# Altium schematic sheet Schematic2.SchDoc
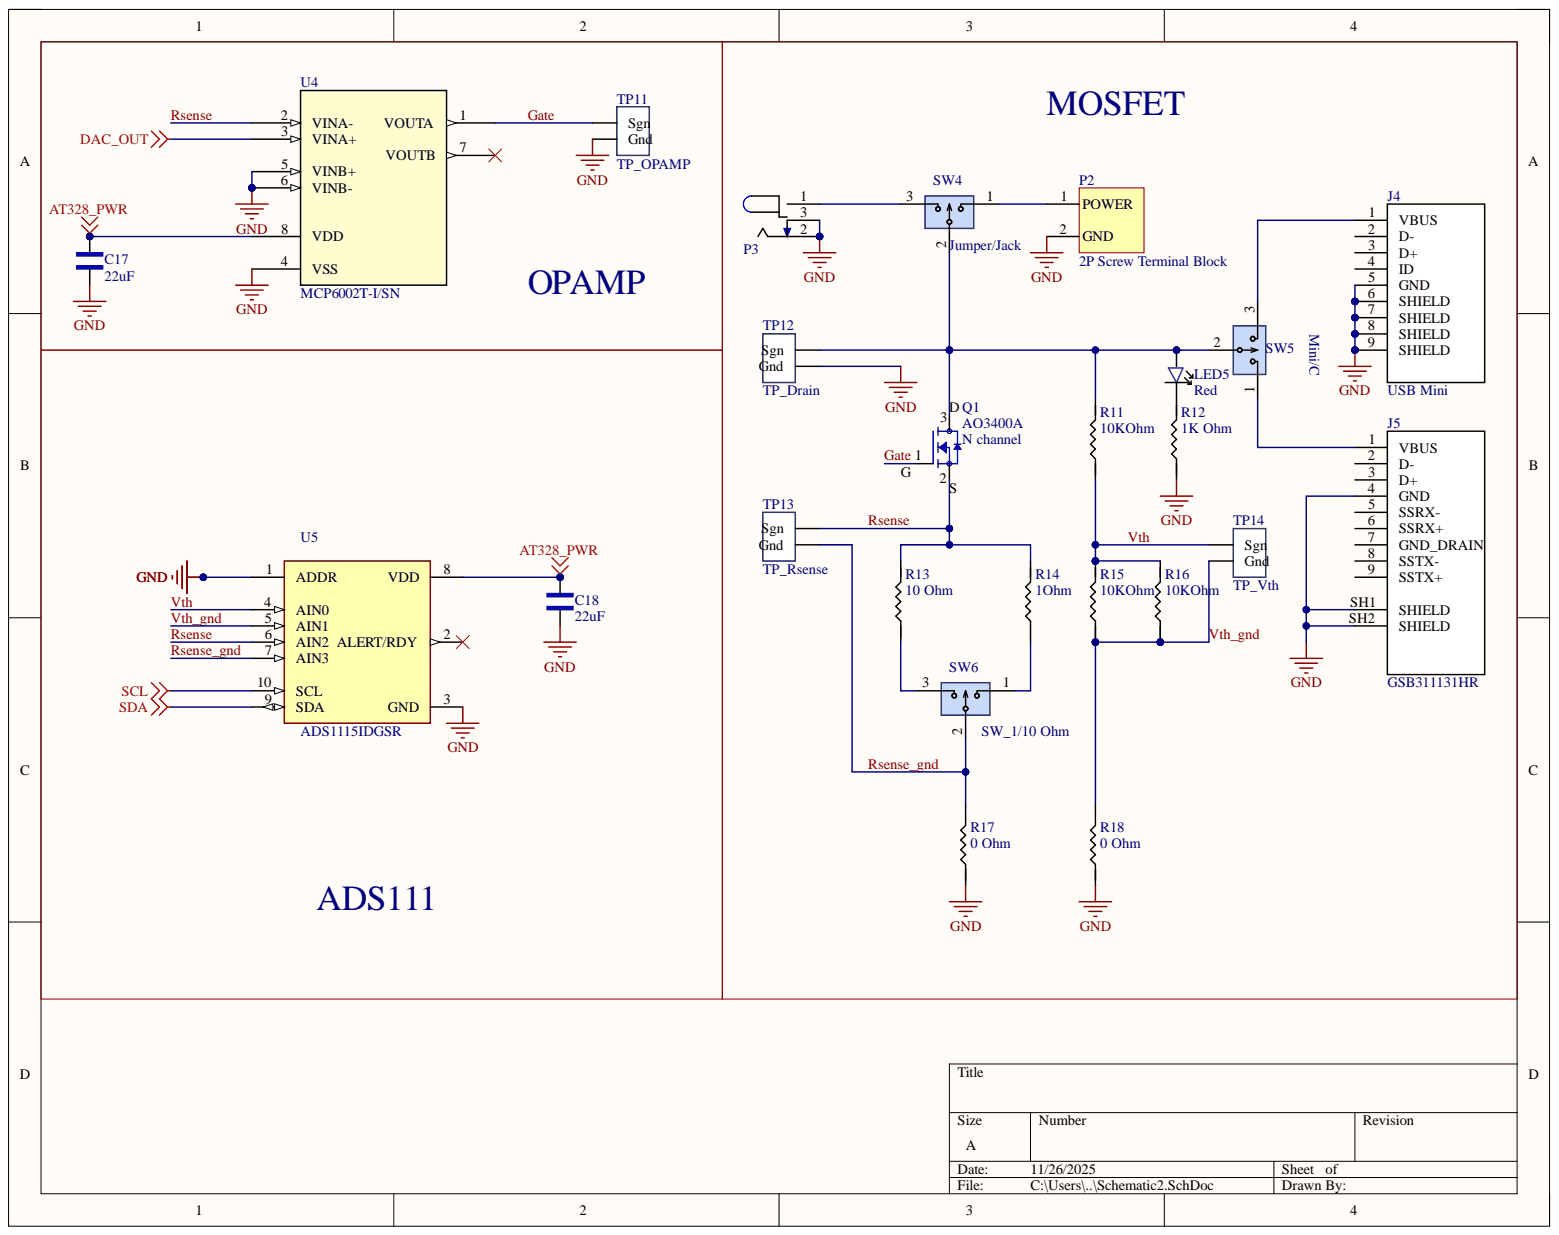
[diagram p0: Schematic2.SchDoc]

## Parts
C17  22uF  [1206_Passive_Capacitor]
C18  22uF  [1206_Passive_Capacitor]
J4  USB Mini  [USB_MINI_B]
J5  GSB311131HR  [USB_3A_Receptacle]
LED5  Red  [LED_1206]
P2  2P Screw Terminal Block  [P_Terminal block 3.5mm-pitch]
P3  Power Jack  [Power_Jack]  (value not drawn)
Q1  AO3400A  value N channel  [N-MOSFET]
R11  value 10KOhm  [1206_Passive_Resitor]  (hidden comment: 10k)
R12  value 1K Ohm  [1206_Passive_Resitor]  (hidden comment: 1k)
R13  10 Ohm  [1206_Passive_Resitor]
R14  1 Ohm  value 1Ohm  [1206_Passive_Resitor]
R15  value 10KOhm  [1206_Passive_Resitor]  (hidden comment: 10k)
R16  value 10KOhm  [1206_Passive_Resitor]  (hidden comment: 10k)
R17  0 Ohm  [1206_Passive_Resitor]
R18  0 Ohm  [1206_Passive_Resitor]
SW4  Jumper/Jack  [3_PIN_100mil]
SW5  Mini/C  [3_PIN_100mil]
SW6  SW_1/10 Ohm  [3_PIN_100mil]
TP11  TP_OPAMP  [TP10x_Probe]
TP12  TP_Drain  [TP10x_Probe]
TP13  TP_Rsense  [TP10x_Probe]
TP14  TP_Vth  [TP10x_Probe]
U4  MCP6002T-I/SN  [SOIC127P600X175-8N]
U5  ADS1115IDGSR  [ADC_ADS1115IDGSR]

## Nets
AT328_PWR: C17.2 C18.2 U4.8(VDD) U5.8(VDD)
DAC_OUT: U4.3(VINA+)
GND: C17.1 C18.1 J4.5(GND) J4.6(SHIELD) J4.7(SHIELD) J4.8(SHIELD) J4.9(SHIELD) J5.4(GND) J5.SH1(SHIELD) J5.SH2(SHIELD) P2.2 P3.2 P3.3 R12.1 R17.1 R18.1 TP11.2 TP12.2 U4.4(VSS) U4.5(VINB+) U4.6(VINB-) U5.1(ADDR) U5.3(GND)
SCL: U5.10(SCL)
SDA: U5.9(SDA)
Gate: Q1.1 TP11.1 U4.1(VOUTA)
Rsense: Q1.2 R13.2 R14.2 TP13.1 U4.2(VINA-) U5.6(AIN2)
Rsense_gnd: R17.2 SW6.2 TP13.2 U5.7(AIN3)
Vth: R11.1 R15.2 R16.2 TP14.1 U5.4(AIN0)
Vth_gnd: R15.1 R16.1 R18.2 TP14.2 U5.5(AIN1)
NetJ4_1: J4.1(VBUS) SW5.3
NetJ5_1: J5.1(VBUS) SW5.1
NetLED5_1: LED5.1 Q1.3 R11.2 SW4.2 SW5.2 TP12.1
NetLED5_2: LED5.2 R12.2
NetP2_1: P2.1 SW4.1
NetP3_1: P3.1 SW4.3
NetR13_1: R13.1 SW6.3
NetR14_1: R14.1 SW6.1

Not connected: J4 2(D-) 3(D+) 4(ID); J5 2(D-) 3(D+) 5(SSRX-) 6(SSRX+) 7(GND_DRAIN) 8(SSTX-) 9(SSTX+); U4 7(VOUTB); U5 2(ALERT/RDY)

## Library data of the parts
C17 C18 = C_22uF_1206: 22uF ±10% 25V X5R 1206 Multilayer Ceramic Capacitors MLCC - SMD/SMT RoHS; MFR Part #: CL31A226KAHNNNE; Type: basic; Component Kind: Standard; Datasheet: https://datasheet.lcsc.com/lcsc/1811031514_Samsung-Electro-Mechanics-CL31A226KAHNNNE_C12891.pdf; LCSC Part#: C12891; Library Name: ECEN5730Lib_2023_05_DS.IntLib; Value: 22uF
J4 = J_USB_B_Mini: Through Hole USB Connectors RoHS; MFR Part #: 920-462A2021D10102; Value: USB mini B; Component Kind: Standard; Datasheet: https://datasheet.lcsc.com/szlcsc/2103081233_Jing-Extension-of-the-Electronic-Co-920-462A2021D10102_C46398.pdf; LCSC Part#: C46398; Library Name: ECEN5730Lib_2023_05_DS.IntLib; Type: Extended
J5 = J_USB3A_Receptacle: USB-A _USB TYPE-A_ USB 3.0 _USB 3.1 Gen 1, Superspeed_ Receptacle Connector 9 Position Through Hole, Right Angle; Component Kind: Standard; Datasheet: https://datasheet.lcsc.com/szlcsc/1811131822_Jing-Extension-of-the-Electronic-Co-901-112A105AD10100_C69073.pdf; DigiKey Link: https://www.digikey.com/en/products/detail/amphenol-icc-commercial-products/GSB311131HR/2187173?utm_source=snapeda&utm_medium=aggregator&utm_campaign=symbol; LCSC Part#: C69073; Library Name: ECEN5730Lib_2023_05_DS.IntLib; MFR Part #: GSB311131HR
LED5 = LED_RED_1206: Red 621~631nm 1206 Light Emitting Diodes (LED) RoHS; MFR Part #: 15-21/R6C-FQ1R1B/2T; Type: Extended; Component Kind: Standard; Datasheet: https://datasheet.lcsc.com/szlcsc/1811151241_Everlight-Elec-15-21-R6C-FQ1R1B-2T_C93133.pdf; LCSC Part#: C93133; Library Name: ECEN5730Lib_2023_05_DS.IntLib; Value: Red
P2 = P_Terminal block: Green Straight pin 3.5mm  pitch; P=3.5mm  Screw terminal ROHS; Manufacturer: Cixi Kefa Elec; MFR Part #: KF350-3.5-2P; Component Kind: Standard; DataSheet: https://datasheet.lcsc.com/lcsc/2001160007_Cixi-Kefa-Elec-KF350-3-5-2P_C474892.pdf; LCSC Part#: C474892; Library Name: ECEN5730Lib_2023_05_DS.IntLib
P3 = P_Power Jack: Power Barrel Connector Jack 2.10mm ID (0.083"), 5.50mm OD (0.217") Through Hole, Right Angle; MFR Part #: PJ-102A; Value: CONN PWR JACK 2X5.5MM SOLDER; Component Kind: Standard; Digikey Link: https://www.digikey.com/product-detail/en/PJ-102A/CP-102A-ND/275425/?itemSeq=304982367; LCSC Part#: C136744; Library Name: ECEN5730Lib_2023_05_DS.IntLib; Type: Extended
Q1 = Q_Nch_MOSFET: MOSFET N Trench 30V 5.7A 1.5V @ 250uA 26.5 m? @ 5.7A,10V SOT-23-3L RoHS; Type: Basic; Component Kind: Standard; Datasheet: https://datasheet.lcsc.com/szlcsc/Alpha-Omega-Semicon-AOS-AO3400A_C20917.pdf; LCSC Part#: C20917; Library Name: ECEN5730Lib_2023_05_DS.IntLib; MFR Part #: AO3400A
R11 R15 R16 = R_10KOhm_1206: 10 kOhms ±5% 0.25W, 1/4W Chip Resistor 1206 (3216 Metric) Automotive AEC-Q200 Thick Film; MFR Part #: RMCF1206FT10K0; Component Kind: Standard; Digikey Link: https://www.digikey.com/en/products/detail/stackpole-electronics-inc/RMCF1206FT10K0/1759669; LCSC Part#: C17902; Library Name: ECEN5730Lib_2023_05_DS.IntLib; Type: Basic
R12 = R_1K_1206: CHIP RESISTOR - SURFACE MOUNT 1KOHMS ±1% 1/4W 1206 ROHS; MFR Part #: RNCP1206FTD1K00CT; Component Kind: Standard; Digikey Link: https://www.digikey.com/product-detail/en/RNCP1206FTD1K00/RNCP1206FTD1K00CT-ND/2240675/?itemSeq=304982373; LCSC Part#: C4410; Library Name: ECEN5730Lib_2023_05_DS.IntLib; Type: Basic
R13 = R_10Ohm_1206: CHIP RESISTOR - SURFACE MOUNT 0.1OHMS ±1% 1/4W 1206 ROHS; MFR Part #: 1206W4F100JT5E; Component Kind: Standard; Datasheet: https://datasheet.lcsc.com/szlcsc/Uniroyal-Elec-1206W4F100JT5E_C17903.pdf; LCSC Part#: C17903; Library Name: ECEN5730Lib_2023_05_DS.IntLib; Type: Basic; Value: 10 Ohm
R14 = R_1Ohm_1206: 1Ω ±5% 0.25W ±400ppm/℃ 1206 Chip Resistor - Surface Mount RoHS; MFR Part #: 1206W4J010JT5E; Component Kind: Standard; Datasheet: https://datasheet.lcsc.com/lcsc/1810301410_UNI-ROYAL-Uniroyal-Elec-1206W4J010JT5E_C1409.pdf; LCSC Part#: C1409; Library Name: ECEN5730Lib_2023_05_DS.IntLib; Type: Extended
R17 R18 = R_0Ohm_1206: CHIP RESISTOR - SURFACE MOUNT 0OHMS ±1% 1/4W 1206 ROHS; MFR Part #: 1206W4F0000T5E; Component Kind: Standard; Datasheet: https://datasheet.lcsc.com/szlcsc/Uniroyal-Elec-1206W4F0000T5E_C17888.pdf; LCSC Part#: C17888; Library Name: ECEN5730Lib_2023_05_DS.IntLib; Mouser link: https://www.mouser.com/ProductDetail/Royalohm/1206W4F0000T5E?qs=e8oIoAS2J1Thbopin%252BsagQ%3D%3D; Type: Basic; Value: 0 Ohm
SW4-SW6 = SW_3Pin_100mil_Switch: Connector Header Through Hole 3 position 0.100" (2.54mm); MFR Part #: PREC003SAAN-RC; Value: CONN HEADER VERT 3POS 2.54MM; Component Kind: Standard; Digikey Link: https://www.digikey.com/product-detail/en/PREC003SAAN-RC/S1012EC-03-ND/2774851/?itemSeq=304982371; LCSC Part#: C49257; Library Name: ECEN5730Lib_2023_05_DS.IntLib; Type: Extended
TP11-TP14 = TP_10x_Probe: Test Point 300 mil centers; Component Kind: Standard; Library Name: ECEN5730Lib_2023_05_DS.IntLib
U4 = U_MCP6002T-I/SN: 0.6 V/us 2 1MHz 1.8V ~ 6V 100μA General Purpose SOIC-8_150mil  Operational Amplifier ROHS; Mfr: Microchip; Component Kind: Standard; DataSheet: https://ww1.microchip.com/downloads/en/DeviceDoc/MCP6001-1R-1U-2-4-1-MHz-Low-Power-Op-Amp-DS20001733L.pdf; LCSC Part#: C7377; Library Name: ECEN5730Lib_2023_05_DS.IntLib; MFR Part #: MCP6002T-I/SN; Type: Basic
U5 = U_ADC_ADS1115IDGSR: 16-Bit ADC with Integrated MUX, PGA, Comparator, Oscillator, and Reference 10-VSSOP -40 to 125; Component Kind: Standard; DigiKey link: https://www.digikey.com/product-detail/en/texas-instruments/ADS1115IDGSR/296-38849-1-ND/5142969?utm_source=snapeda&utm_medium=aggregator&utm_campaign=symbol; LCSC Part#: C37593; Library Name: ECEN5730Lib_2023_05_DS.IntLib; MFR Part #: ADS1115IDGSR; Package: MSOP-10 Texas Instruments; SnapEDA_Link: https://www.snapeda.com/parts/ADS1115IDGSR/Texas%20Instruments/view-part/?ref=snap; Type: Extended
